# Altium schematic sheet LPS22HHTR.SchDoc(LPS22HHTR)
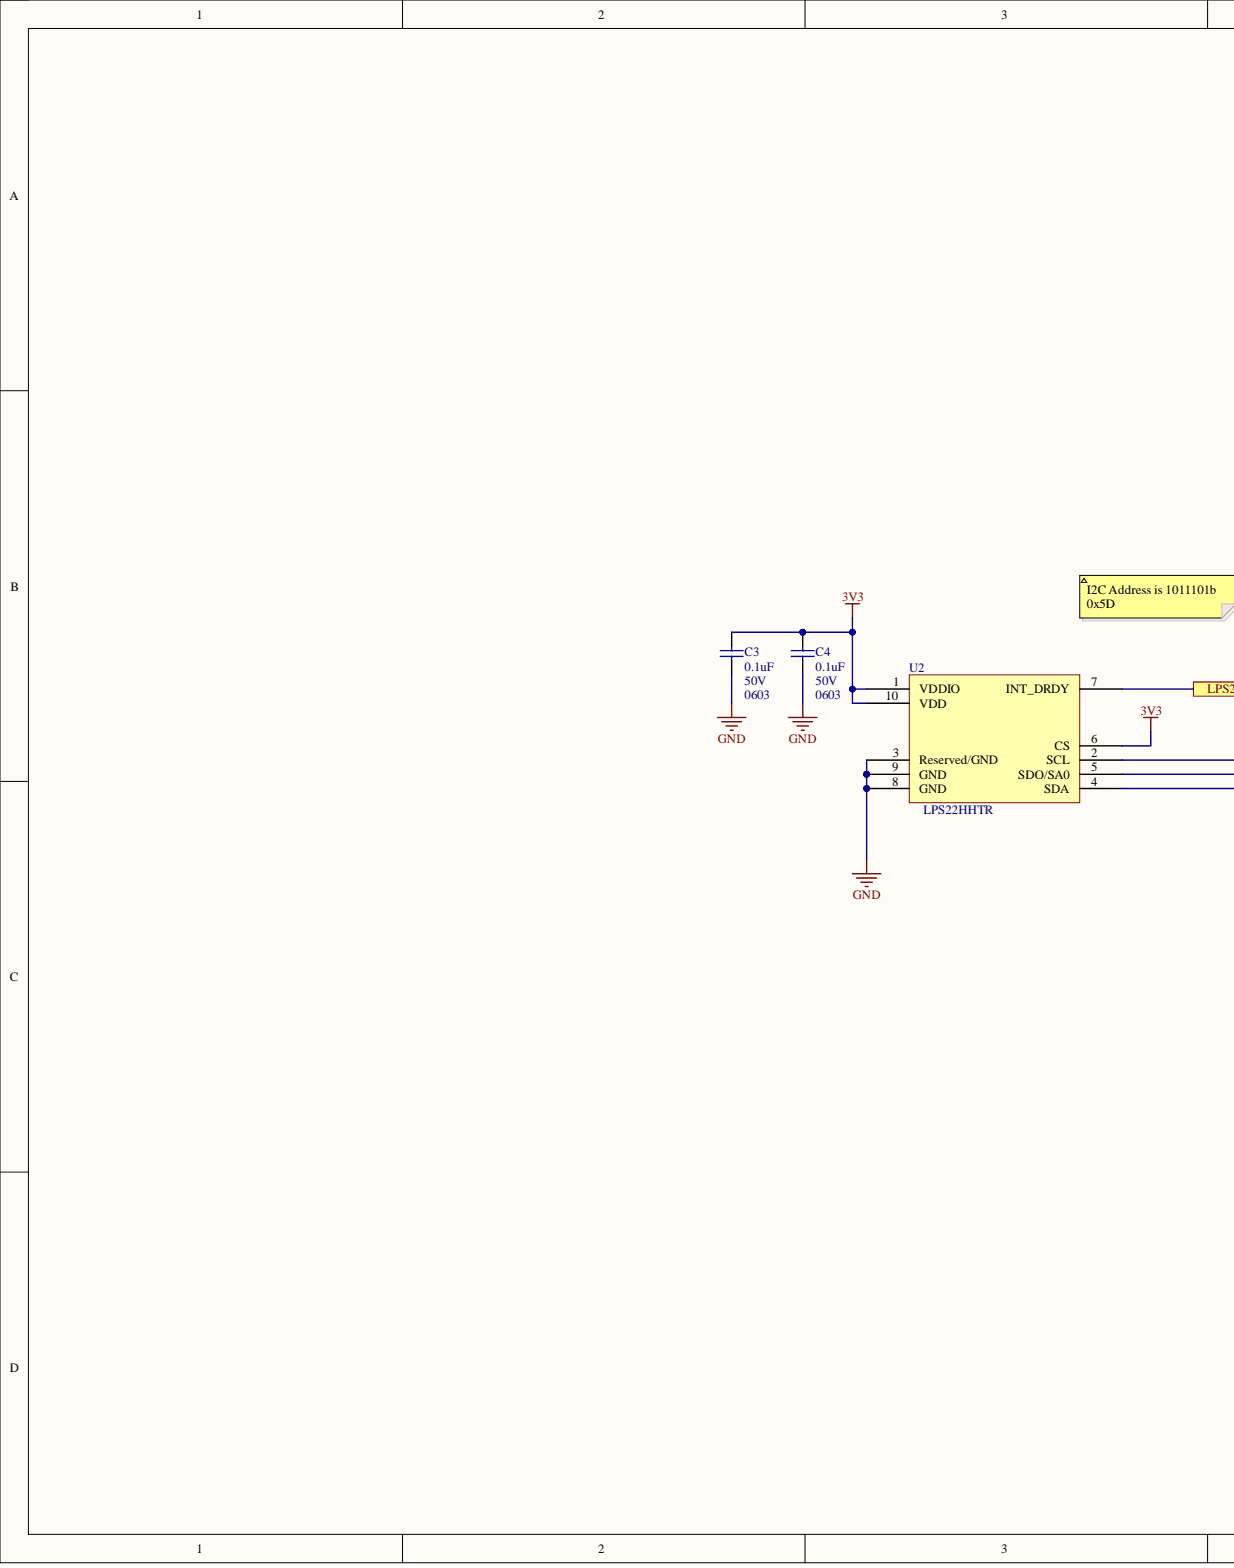
[diagram p0: LPS22HHTR.SchDoc, left]
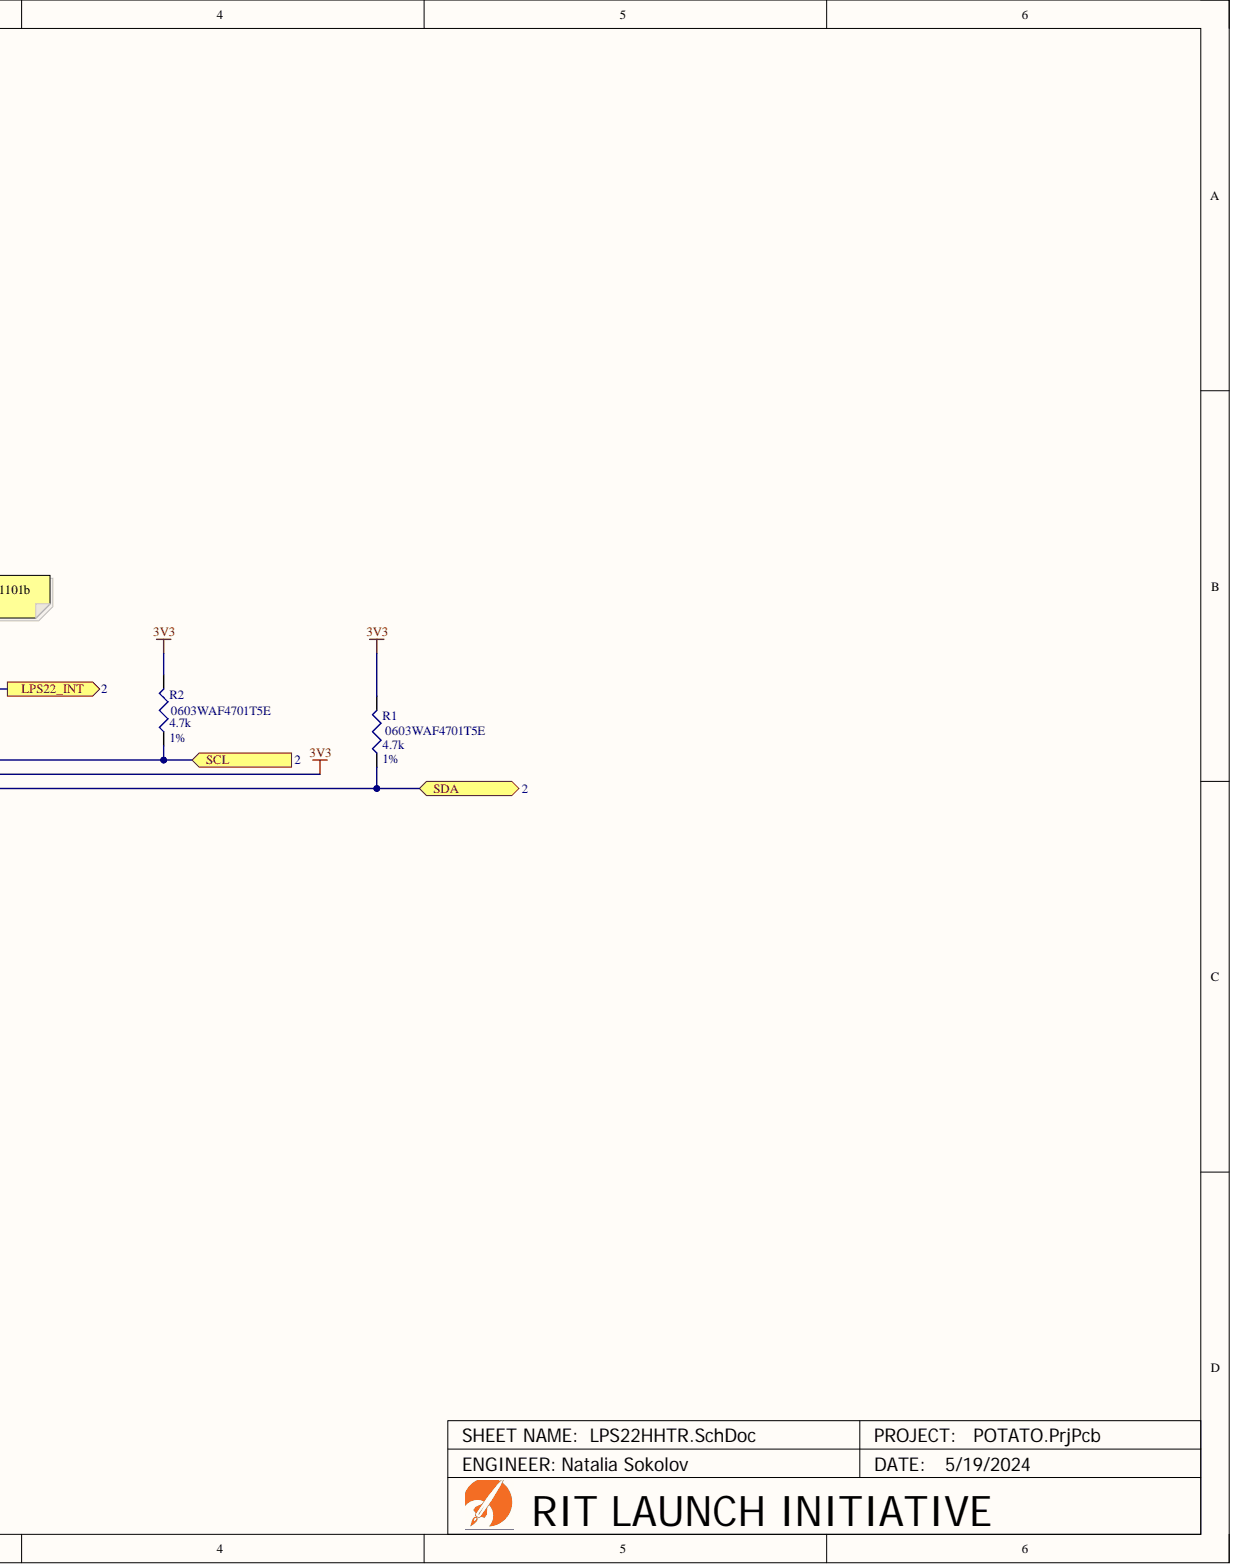
[diagram p1: LPS22HHTR.SchDoc, right]

## Parts
C3  Capacitance: 0.1uF  Case Code (Imperial): 0603  Voltage: 50V  (hidden comment: CC0603KRX7R9BB104)
C4  Capacitance: 0.1uF  Case Code (Imperial): 0603  Voltage: 50V  (hidden comment: CC0603KRX7R9BB104)
R1  0603WAF4701T5E  Resistance: 4.7k  Tolerance: 1%
R2  0603WAF4701T5E  Resistance: 4.7k  Tolerance: 1%
U2  LPS22HHTR

## Ports
LPS22_INT, SCL, SDA

## Nets
3V3: C3.2 C4.2 R1.2 R2.2 U2.1(VDDIO) U2.5(SDO/SA0) U2.6(CS) U2.10(VDD)
GND: C3.1 C4.1 U2.3(Reserved/GND) U2.8(GND) U2.9(GND)
LPS22_INT: U2.7(INT_DRDY) ; port LPS22_INT
SCL: R2.1 U2.2(SCL) ; port SCL
SDA: R1.1 U2.4(SDA) ; port SDA

## Library data of the parts
C3 C4 = CMP-001-0242-3: CAP CER 0.1UF 50V X7R 0603; Dielectric Material: Ceramic; Tolerance: 10%; Case Code (Metric): 1608; Case/Package: 0603; Component Kind: Standard; Depth: 0.8mm; Dielectric: X7R; Halogen Free: Halogen Free; Height: 0.8mm; JLCPCB Part #: C14663; Lead Free: Lead Free; Length: 0.063inch; Max Operating Temperature: 125°C; Min Operating Temperature: -55°C; Mount: Surface Mount; Number of Pins: 2; Package Quantity: 1; Packaging: Tape and Reel; Radiation Hardening: No; REACH SVHC: No SVHC; RoHS Compliant: Yes; Series: CC; Termination: SMD/SMT; Thickness: 0.035inch; Voltage Rating: 50V; Width: 0.031inch; Library Name: RIT Launch Initiative
R1 R2 = CMP-001-0283-3: 100mW Thick Film Resistors 75V ±100ppm/℃ ±1% -55℃~+155℃ 4.7kΩ 0603 Chip Resistor - Surface Mount ROHS; Component Kind: Standard; JLCPCB Part #: C23162; Package: 0603; Library Name: RIT Launch Initiative
U2 = CMP-001-0377-5: Pressure Sensor; Sensor Type: PRESSURE SENSOR,PEIZORESISTIVE; Component Kind: Standard; JLCPCB Part #: C2827824; Reach SVHC Compliant: Yes; RoHS Compliant: Yes; Library Name: RIT Launch Initiative
